# Altium schematic sheet Xu-ly_tieng_noi.SchDoc(Xu-ly_tieng_noi)
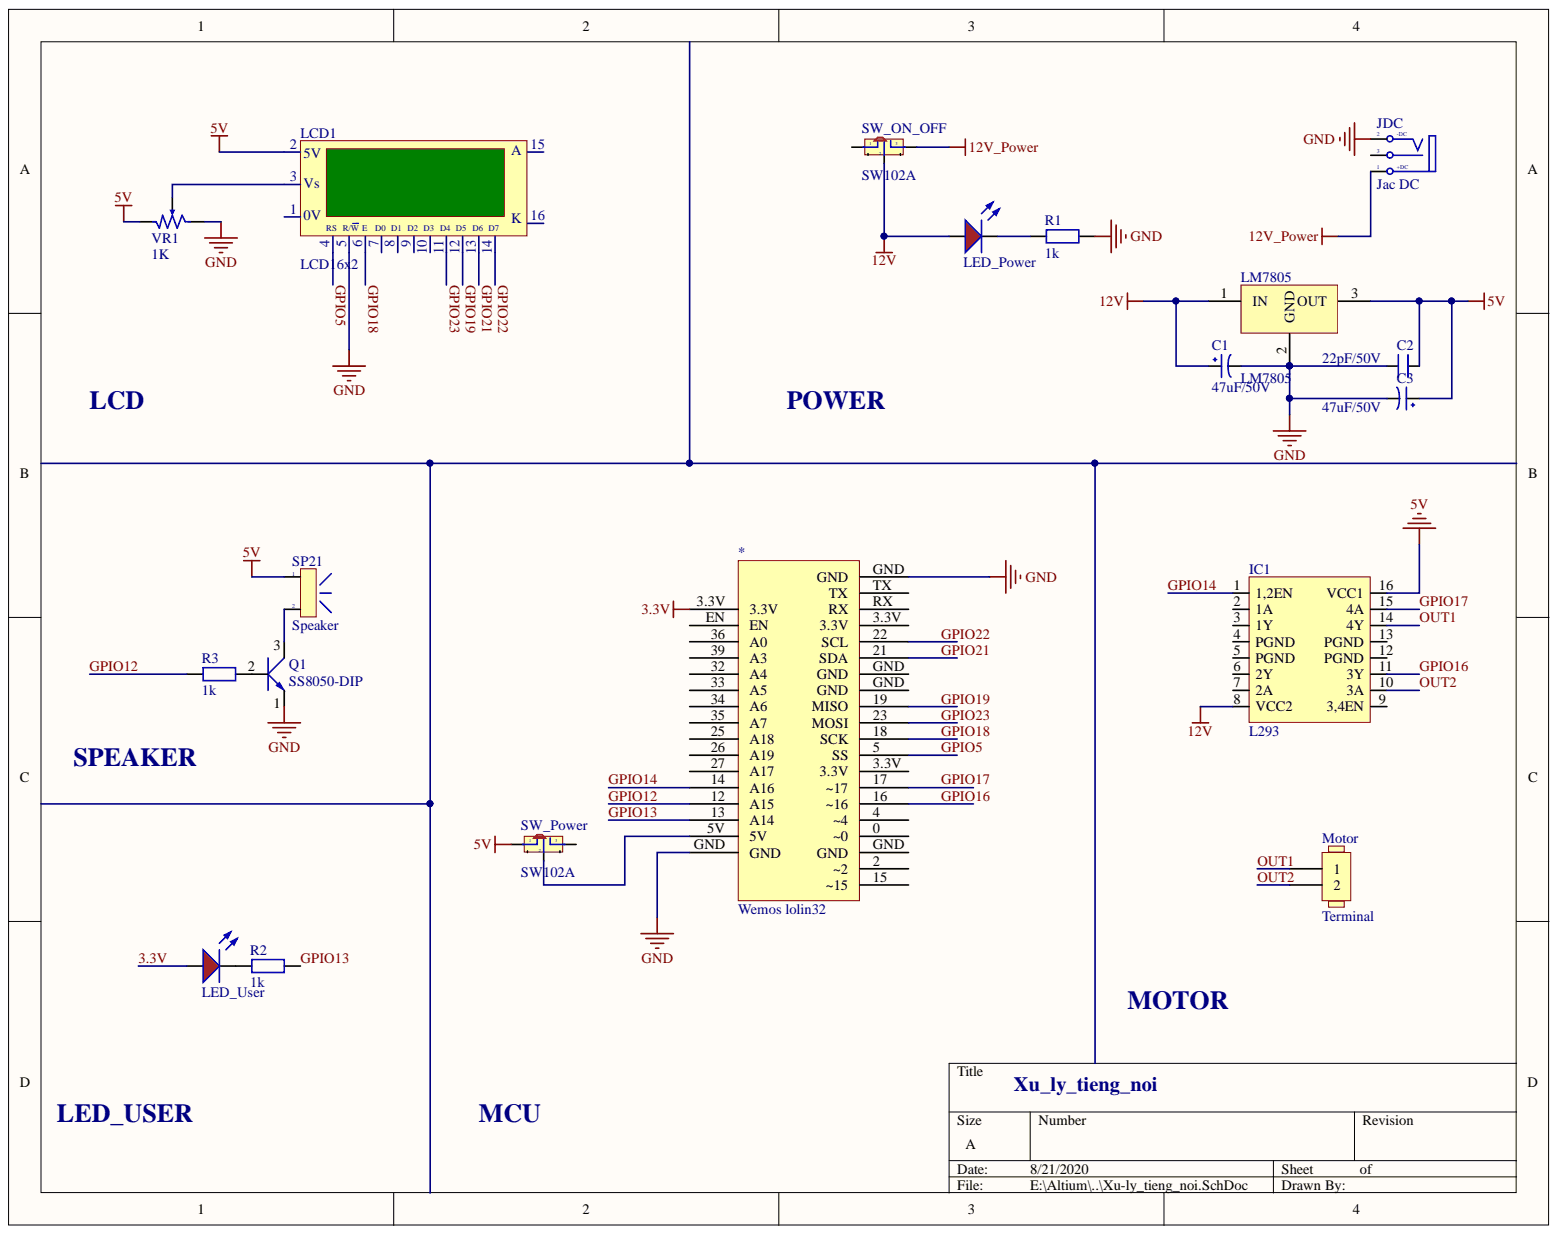
[diagram p0: Xu-ly_tieng_noi.SchDoc]

## Parts
*  Wemos lolin32  [Wemos lolin32]
C1  47uF/50V  [CAP_xUF_50V_TH_6.3x11]
C2  22pF/50V  [CAP_10x_TH]
C3  47uF/50V  [CAP_xUF_50V_TH_6.3x11]
IC1  L293  [L293]
JDC  Jac DC  [DC socket]
LCD1  LCD16x2  [LCD16x2]
LED_Power  LED_RED  [LED5R5mm]  (value not drawn)
LED_User  LED_RED  [LED5R5mm]  (value not drawn)
LM7805  LM7805  [QTO-220H3]
Motor  Terminal  [Terminal x2 5mm]
Q1  SS8050-DIP  [SS8050DIP]
R1  1k  [R1/4W]
R2  1k  [R1/4W]
R3  1k  [R1/4W]
SP21  Speaker  [Speaker]
SW_ON_OFF  SW102A  [SW102]
SW_Power  SW102A  [SW102]
VR1  1K  [Trimmer 3262]

## Nets
5V: C2.2 C3.1 IC1.16(VCC1) LCD1.2(5V) LM7805.3 SP21.1 SW_Power.1 VR1.1
12V: C1.1 IC1.8(VCC2) LED_Power.1 LM7805.1 SW_ON_OFF.2
12V_Power: JDC.1 SW_ON_OFF.3
GND: *.GND C1.2 C2.1 C3.2 JDC.2 LCD1.5 LM7805.2 Q1.1 R1.2 VR1.3
3.3V: *.3.3V LED_User.1
GPIO5: *.5(SS) LCD1.4(RS)
GPIO12: *.12(A15) R3.1
GPIO13: *.13(A14) R2.2
GPIO14: *.14(A16) IC1.1(1,2EN)
GPIO16: *.16(~16) IC1.11(3Y)
GPIO17: *.17(~17) IC1.15(4A)
GPIO18: *.18(SCK) LCD1.6(E)
GPIO19: *.19(MISO) LCD1.12(D5)
GPIO21: *.21(SDA) LCD1.13(D6)
GPIO22: *.22(SCL) LCD1.14(D7)
GPIO23: *.23(MOSI) LCD1.11(D4)
OUT1: IC1.14(4Y) Motor.1
OUT2: IC1.10(3A) Motor.2
Net*_5V: *.5V SW_Power.2
NetLCD1_3: LCD1.3(Vs) VR1.2
NetLED_Power_2: LED_Power.2 R1.1
NetLED_User_2: LED_User.2 R2.1
NetQ1_2: Q1.2 R3.2
NetQ1_3: Q1.3 SP21.2
N00017:

Not connected: * 0(~0) 2(~2) 4(~4) 15(~15) 25(A18) 26(A19) 27(A17) 32(A4) 33(A5) 34(A6) 35(A7) 36(A0) 39(A3) EN RX TX; IC1 2(1A) 3(1Y) 4(PGND) 5(PGND) 6(2Y) 7(2A) 9(3,4EN) 12(PGND) 13(PGND); JDC 3; LCD1 1(0V) 7(D0) 8(D1) 9(D2) 10(D3) 15(A) 16(K); SW_ON_OFF 1 4 5; SW_Power 3 4 5

## Library data of the parts
C1 C3 = CAP_ALU/CHE_47uF_50V_POL_6.3x11: Use for 33/47 uF Capacitor Through Hole; Component Kind: Standard; Library Name: Duc.SchLib
C2 = CAP_CER_22pF_50V_NPOL: Ceramic Capacitor 22pF/50V (+-10% TH)/(+5%SMD); Component Kind: Standard; Library Name: Duc.SchLib
JDC = DCIN: Jac DC input; Value: Jac DC; Component Kind: Standard; Library Name: Duc.SchLib
LED_Power LED_User = LED_RED: LED Red; Value: Red; Unit Price: 0.0476; ComponentLink1Description: Datasheet; Component Kind: Standard; Library Name: Duc.SchLib; ComponentLink1URL: D:\Dropbox\Datasheets\Opto and Illumination\LEDs\SMD LED.pdf
LM7805 = LM78xx: On ap +, from + 5 to + 24VDC; Value: LM7805; Library Name: My Library.SchLib; Component Kind: Standard; : LM7808; : LM7812; : LM7809; : LM7815
Motor = Terminal x2: Description: Terminal x2; Component Kind: Standard; Library Name: Duc.SchLib
Q1 = SS8050-DIP: NPN transistor through hole; Component Kind: Standard; Library Name: Duc.SchLib
R1-R3 = R1/4W: Resistor 1/4W; Value: R1/4W - 1K; Library Name: My Library.SchLib; Component Kind: Standard
SP21 = Speaker: Buzzer through hole (3V3/5V/12V); Component Kind: Standard; Library Name: Duc.SchLib
SW_ON_OFF SW_Power = SW102A: Switch 102 on Broad; Value: Switch 102 On Board; Library Name: My Library.SchLib; Component Kind: Standard
VR1 = Variable Resistor: WH148; Component Kind: Standard; Library Name: Duc.SchLib
* = Wemos lolin32: Component Kind: Standard; Library Name: Duc.SchLib
IC1 = L293: Component Kind: Standard; Library Name: Duc.SchLib
LCD1 = LCD16x2: Component Kind: Standard; Library Name: Duc.SchLib
